# Altium schematic sheet [01]_PWR.SchDoc([01]_PWR)
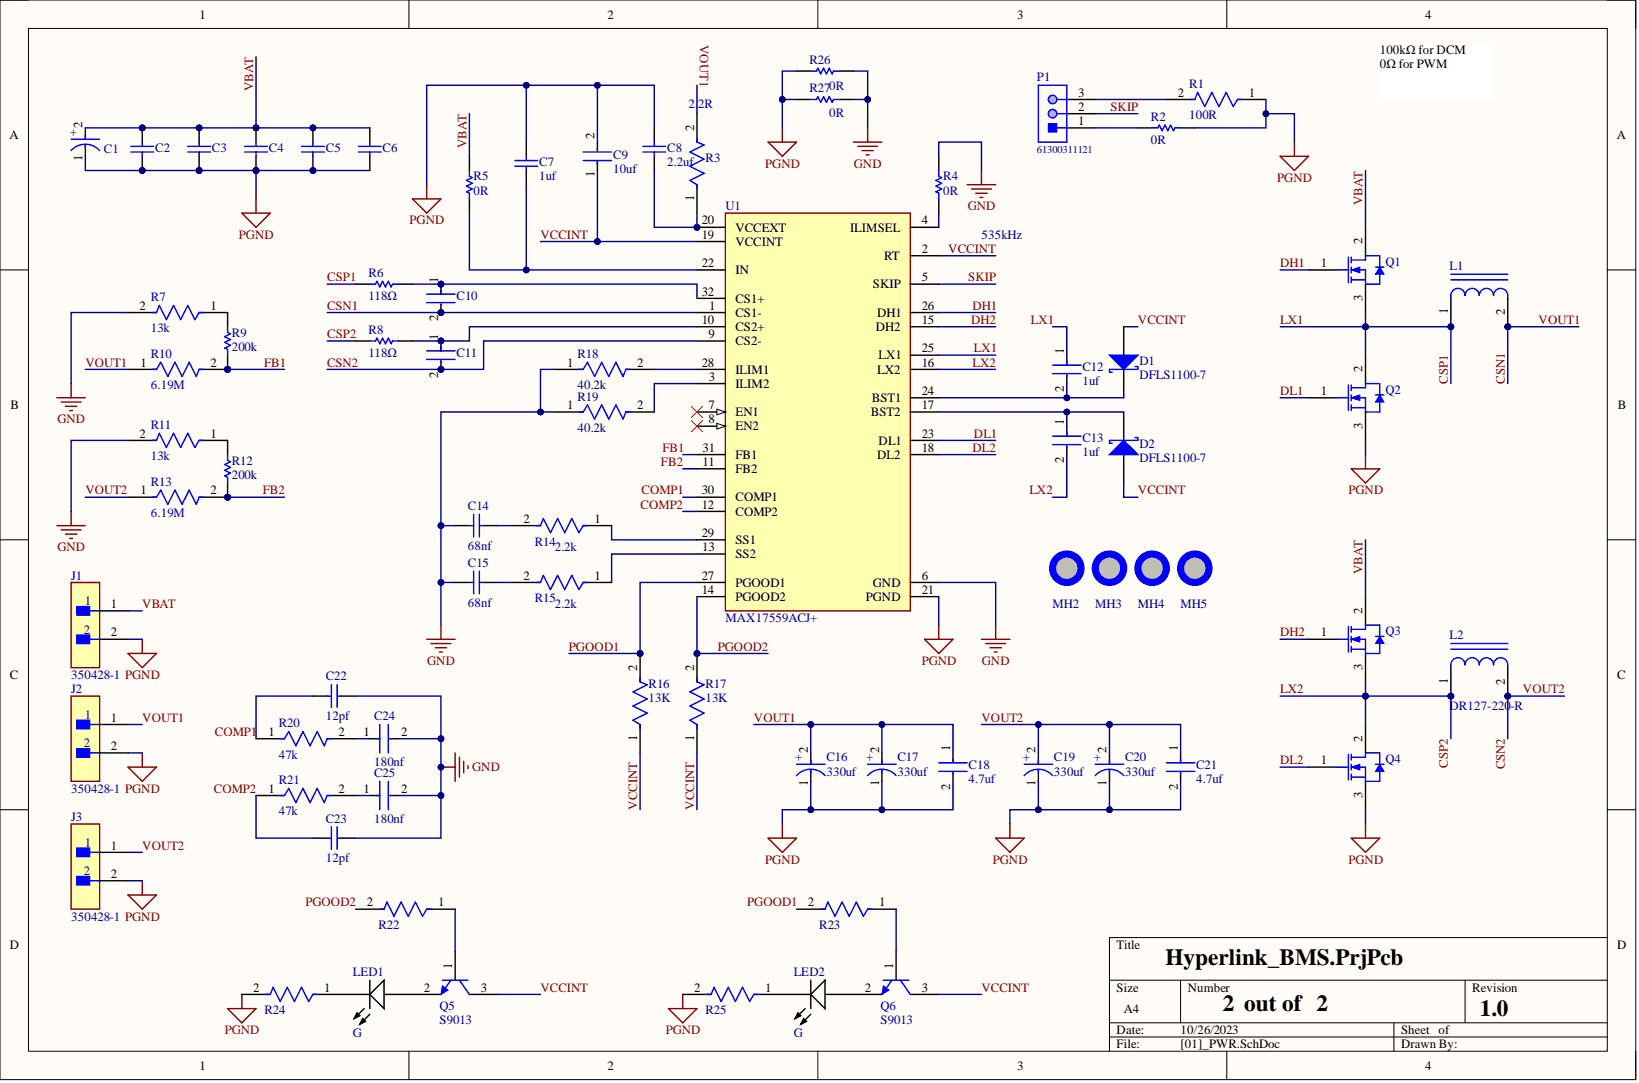
[diagram p0: [01]_PWR.SchDoc]

## Parts
C1  220uf  (value not drawn)
C2  CAP 4.7uF 25V 1210(3225)  (value not drawn)
C3  CAP 4.7uF 25V 1210(3225)  (value not drawn)
C4  CAP 4.7uF 25V 1210(3225)  (value not drawn)
C5  CAP 4.7uF 25V 1210(3225)  (value not drawn)
C6  CAP 4.7uF 25V 1210(3225)  (value not drawn)
C7  1uf
C8  2.2uf
C9  10uf
C10  4.7uF  (value not drawn)
C11  4.7uF  (value not drawn)
C12  1uf
C13  1uf
C14  68nf
C15  68nf
C16  330uf
C17  330uf
C18  4.7uf
C19  330uf
C20  330uf
C21  4.7uf
C22  12pf
C23  12pf
C24  180nf
C25  180nf
D1  DFLS1100-7
D2  DFLS1100-7
J1  350428-1
J2  350428-1
J3  350428-1
L1  DR127-220-R
L2  DR127-220-R
LED1  G
LED2  G
MH2  MH_7.4MMNC_3.2MMNC  (value not drawn)  (no BOM)
MH3  MH_7.4MMNC_3.2MMNC  (value not drawn)  (no BOM)
MH4  MH_7.4MMNC_3.2MMNC  (value not drawn)  (no BOM)
MH5  MH_7.4MMNC_3.2MMNC  (value not drawn)  (no BOM)
P1  61300311121
Q1  IPB60R520CP  (value not drawn)
Q2  IPB60R520CP  (value not drawn)
Q3  IPB60R520CP  (value not drawn)
Q4  IPB60R520CP  (value not drawn)
Q5  S9013
Q6  S9013
R1  100R
R2  0R
R3  2.2R
R4  0R
R5  0R
R6  118Ω
R7  13k
R8  118Ω
R9  200k
R10  6.19M
R11  13k
R12  200k
R13  6.19M
R14  2.2k  (value not drawn)
R15  2.2k  (value not drawn)
R16  13K
R17  13K
R18  40.2k
R19  40.2k
R20  47k
R21  47k
R22  0603WAF1200T5E  (value not drawn)
R23  0603WAF1200T5E  (value not drawn)
R24  CRCW06031K00FKEA  (value not drawn)
R25  CRCW06031K00FKEA  (value not drawn)
R26  0R
R27  0R
U1  MAX17559ACJ+

## Nets
GND: C14.1 C15.1 C22.2 C23.2 C24.2 C25.2 R4.1 R7.2 R11.2 R18.1 R19.1 R26.2 R27.2 U1.6(GND)
PGND: C1.1 C2.1 C3.1 C4.1 C5.1 C6.1 C7.2 C8.2 C9.2 C16.1 C17.1 C18.2 C19.1 C20.1 C21.2 J1.2 J2.2 J3.2 Q2.3 Q4.3 R1.1 R2.1 R24.2 R25.2 R26.1 R27.1 U1.21(PGND)
COMP1: C22.1 R20.1 U1.30(COMP1)
COMP2: C23.1 R21.1 U1.12(COMP2)
CSN1: C10.2 C16.2 C17.2 C18.1 J2.1 L1.2 R3.2 R10.1 U1.1(CS1-)
CSN2: C11.2 C19.2 C20.2 C21.1 J3.1 L2.2 R13.1 U1.9(CS2-)
CSP1: C12.1 L1.1 Q1.3 Q2.2 R6.1 U1.25(LX1)
CSP2: C13.2 L2.1 Q3.3 Q4.2 R8.1 U1.16(LX2)
DH1: Q1.1 U1.26(DH1)
DH2: Q3.1 U1.15(DH2)
DL1: Q2.1 U1.23(DL1)
DL2: Q4.1 U1.18(DL2)
FB1: R9.1 R10.2 U1.31(FB1)
FB2: R12.1 R13.2 U1.11(FB2)
PGOOD1: R16.2 R23.2 U1.27(PGOOD1)
PGOOD2: R17.2 R22.2 U1.14(PGOOD2)
SKIP: P1.2 U1.5(SKIP)
VBAT: C1.2 C2.2 C3.2 C4.2 C5.2 C6.2 J1.1 Q1.2 Q3.2 R5.1
VCCINT: C9.1 D1.1 D2.1 Q5.3 Q6.3 R16.1 R17.1 U1.2(RT) U1.19(VCCINT)
NetC7_1: C7.1 R5.2 U1.22(IN)
NetC8_1: C8.1 R3.1 U1.20(VCCEXT)
NetC10_1: C10.1 R6.2 U1.32(CS1+)
NetC11_1: C11.1 R8.2 U1.10(CS2+)
NetC12_2: C12.2 D1.2 U1.24(BST1)
NetC13_1: C13.1 D2.2 U1.17(BST2)
NetC14_2: C14.2 R14.2
NetC15_2: C15.2 R15.2
NetC24_1: C24.1 R20.2
NetC25_1: C25.1 R21.2
NetLED1_1: LED1.1 Q5.2
NetLED1_2: LED1.2 R24.1
NetLED2_1: LED2.1 Q6.2
NetLED2_2: LED2.2 R25.1
NetP1_1: P1.1 R2.2
NetP1_3: P1.3 R1.2
NetQ5_1: Q5.1 R22.1
NetQ6_1: Q6.1 R23.1
NetR4_2: R4.2 U1.4(ILIMSEL)
NetR7_1: R7.1 R9.2
NetR11_1: R11.1 R12.2
NetR14_1: R14.1 U1.29(SS1)
NetR15_1: R15.1 U1.13(SS2)
NetR18_2: R18.2 U1.28(ILIM1)
NetR19_2: R19.2 U1.3(ILIM2)

Not connected: U1 7(EN1) 8(EN2)

## Library data of the parts
C1 = CMP-05427-000076-1: CAP ALUM 220UF 20% 100V SMD; Dielectric Material: Aluminium; ESR (Equivalent Series Resistance): 153mR; Leakage Current: 3uA; Life (Hours): 5000Hour; Ripple Current: 917mA; Capacitance: 220uF; Case/Package: Radial; Component Kind: Standard; Construction: Cylindrical; Contact Plating: Tin; Diameter: 18mm; Height: 16.5mm; Height - Seated (Max): 0.65inch; Impedance: 153mR; JLC: C340711; Lead Free: Lead Free; Length: 17mm; Library Name: Altium Content Vault; Max Operating Temperature: 105°C; Min Operating Temperature: -55°C; Mount: Surface Mount; Number of Pins: 2; Package Quantity: 1; Packaging: Tape and Reel; Radiation Hardening: No; REACH SVHC: No SVHC; Ripple Current (AC): 917mA; RoHS Compliant: Yes; Series: FK; Termination: SMD/SMT; Tolerance: 20%; Voltage: 100V; Voltage Rating: 100V; Voltage Rating (DC): 100V; Width: 1.2mm
C2-C6 = CMP-1038-02151-2: CAP 4.7uF 25V ±10% 1210 (3225 Metric) Thickness 2.2mm SMD; Case-EIA: 1210; Case-Metric: 3225; Tolerance: Â±10%; Component Kind: Standard; JLC: C2840282; Library Name: Altium Content Vault; Max Thickness: 2.2 mm; Rated Voltage: 25 V; Technology: SMT; Value: 4.7uF
C7 = CMP-2000-06366-1: Chip Capacitor, 1 uF, +/- 10%, 100 V, -55 to 125 degC, 1210 (3225 Metric), RoHS, Tape and Reel; Manufacturer: Murata; Capacitance: 1µF; Catalog Drawings: GRMSeries_1210_2.5; Featured Product: GA3SafetyRecognizedCapsandHi-CapMLCC; Online Catalog: GRMSeries; Other Names: 490-1857-6; Product Training Modules: High-CapMultilayerCeramicCapacitors; Temperature Coefficient: X7R; Voltage - Rated: 100V; Applications: GeneralPurpose; Category: Capacitors; Component Kind: Standard; Datasheet URL: http://search.murata.co.jp/Ceramy/image/img/A01X/G101/ENG/GRM32ER72A105KA01-01.pdf; Datasheet Version: 02/2016; Family: CeramicCapacitors; Features: -; Height - Seated (Max): -; JLC: C13832; Lead Spacing: -; Lead Style: -; Library Name: Altium Content Vault; Manufacturer URL: http://www.murata.com/; Mounting Technology: SurfaceMount; Mounting Type: SurfaceMount,MLCC; Operating Temperature: -55°C~125°C; Package / Case: 1210(3225Metric); Package Description: 2-Pin Surface Mount Device, Body 3.2 x 2.5 mm; Package Reference: 1210; Packaging: Digi-Reel®; Ratings: -; Series: GRM; Size / Dimension: 0.126"Lx0.098"W(3.20mmx2.50mm); Standard Package: 1; Thickness (Max): 0.106"(2.70mm); Tolerance: ±10%
C8 = CMP-2007-03455-1: Manufacturer: Murata; Part Number: GRM21BR71C225KA12L; Component Kind: Standard; JLc: C49217; Library Name: Altium Content Vault
C9 = CMP-2006-02792-2: Chip Multilayer Ceramic Capacitors for General Purpose, 0603, 10uF, X5R, 15%, 20%, 6.3V; Capacitance: 10uF; Case Code (Imperial): 0603; Case Code (Metric): 1608; Case/Package: 0603; Component Kind: Standard; Depth: 0.8mm; Dielectric: X5R; Height: 0.8mm; JLC: C19702; Lead Free: Lead Free; Length: 1.6mm; Library Name: Altium Content Vault; Material: Ceramic; Max Operating Temperature: 85°C; Min Operating Temperature: -55°C; Mount: Surface Mount; Package Quantity: 4000; Packaging: Tape and Reel; Radiation Hardening: No; REACH SVHC: No SVHC; Termination: SMD/SMT; Thickness: 0.035inch; Tolerance: 20%; Voltage: 6.3V; Voltage Rating: 6.3V; Voltage Rating (DC): 6.3V; Width: 0.031inch
C10 C11 C18 C21 = CMP-14477-004926-2: Multilayer Ceramic Capacitor 4.7uF 25V X5R 10% SMD 0603 T/R; Capacitance: 4.7uF; Case Code (Imperial): 0603; Case Code (Metric): 1608; Case/Package: 0603; Component Kind: Standard; Contact Plating: Tin; Dielectric: X5R; JLC: C19666; Lead Free: Lead Free; Length: 0.063inch; Library Name: Altium Content Vault; Max Operating Temperature: 85°C; Min Operating Temperature: -55°C; Mount: Surface Mount; Packaging: Tape and Reel; RoHS Compliant: Yes; Termination: Wraparound; Thickness: 0.039inch; Tolerance: 10%; Voltage Rating: 25V; Width: 0.031inch
C12 C13 = CMP-2006-02256-2: CAP CER 4.7UF 6.3V X5R 0603; Manufacturer: Kemet; Manufacturer Part Number: C0603C475K9PACTU; Material: Ceramic; Temperature Coefficient: 15%; Tolerance: 10%; Automotive: No; Capacitance: 4.7uF; Category: Cap; Component Kind: Standard; ComponentLink2Description: Datasheet; ComponentLink2URL: https://content.kemet.com/datasheets/KEM_C1006_X5R_SMD.pdf; Device Class L1: Passive Components; Device Class L2: Capacitors; Device Class L3: Ceramic Capacitors; Digikey Description: CAP CER 4.7UF 6.3V X5R 0603; Height: 0.9mm; Ipc Land Pattern Name: CAPC16080X80; JLC: C15849; Lead Free: Yes; Library Name: Altium Content Vault; Mouser Description: Multilayer Ceramic Capacitors MLCC - SMD/SMT 6.3volts 4.7uF X5R 10%; Octopart: https://octopart.com/c0603c475k9pactu-kemet-104242; Package: C0603-CG; RoHS: TRUE; Temperature: -55°C to +85°C; Temperature Characteristic: X5R; Temperature Range High: +85°C; Temperature Range Low: -55°C; Value: 4.7uF; Voltage Rating: 6.3V
C14 C15 = CMP-2006-02098-1: Manufacturer: AVX Interconnect / Elco; Part Number: 0603YC683KAZ2A; Component Kind: Standard; JLC: C31658; Library Name: Altium Content Vault
C16 C17 C19 C20 = CMP-05427-000096-1: CAP ALUM 330UF 20% 35V SMD; Dielectric Material: Aluminium; ESR (Equivalent Series Resistance): 80mR; Lead Diameter: 0.9mm; Leakage Current: 115.5uA; Life (Hours): 2000Hour; Ripple Current: 850mA; Capacitance: 330uF; Case/Package: Radial; Component Kind: Standard; Construction: Cylindrical; Contact Plating: Tin; Diameter: 8mm; Features: General Purpose; Height: 10.2mm; Height - Seated (Max): 0.413inch; JLC: C310848; Lead Free: Lead Free; Lead Pitch: 3.1mm; Length: 10.2mm; Library Name: Altium Content Vault; Max Operating Temperature: 105°C; Min Operating Temperature: -55°C; Mount: Surface Mount; Number of Pins: 2; Package Quantity: 500; Packaging: Tape and Reel; Radiation Hardening: No; REACH SVHC: No SVHC; Ripple Current (AC): 850mA; RoHS Compliant: Yes; Series: FT; Termination: SMD/SMT; Tolerance: 20%; Voltage: 35V; Voltage Rating: 35V; Voltage Rating (DC): 35V
C22 C23 = CMP-2006-04614-1: Manufacturer: AVX Interconnect / Elco; Part Number: 06035J120GBSTR; Component Kind: Standard; JLC: C38523; Library Name: Altium Content Vault
C24 C25 = CMP-1035-02634-3: CAP CER 0.18UF 25V X7R 0603; Manufacturer: KEMET; Manufacturer Part Number: C0603C184K3RACTU; Automotive Grade: Grade 1; Capacitance: 0.18 μF; Material: Ceramic; Temperature Coefficient: 30ppm/°C; Tolerance: 0.1; Value: 0.18 μF; Automotive: Yes; Category: Cap; Component Kind: Standard; ComponentLink2Description: Datasheet; ComponentLink2URL: https://api.kemet.com/component-edge/download/datasheet/C0603C100J5GACAUTO.pdf; Device Class L1: Passive Components; Device Class L2: Capacitors; Device Class L3: Ceramic Capacitors; Digikey Description: CAP CER 0.18UF 25V X7R 0603; Height: 0.87mm; JLC: C605213; Lead Free: Yes; Library Name: Altium Content Vault; Octopart: https://octopart.com/c0603c184k3ractu-kemet-19757378; Package: C0603C-CF; RoHS: TRUE; Temperature: -55°C to +125°C; Temperature Characteristic: X7R; Temperature Range High: +125°C; Temperature Range Low: -55°C; Voltage Rating: 25 V
D1 D2 = CMP-2000-05099-1: 1 A High Voltage Schottky Barrier Rectifier, 0.62 V, -55 to 175 degC, 2- Pin POWERDI123, Pb-Free, Tape and Reel; Manufacturer: Diodes Inc; Average Rectified Current-Max: 1A; Forward Voltage: 0.77V; Peak Current-Max: 40A; Reverse Current-Max: 1µA; Reverse Voltage-Max [Vrrm]: 100V; Component Kind: Standard; Datasheet URL: https://datasheet.ciiva.com/15144/getdatasheetpartid-44146-15144126.pdf; Datasheet Version: Sep-14; JLC: C107674; Library Name: Altium Content Vault; Manufacturer URL: http://www.diodes.com/
J1-J3 = CMP-03369-000080-1: CONN HEADER VERT 2POS 6.35MM; Manufacturer: TE Connectivity; Conn Gender: Male; Conn Orientation: Vertical; Current Rating: 12A; Number Of Contacts: 2; Standoff Height: 0.63mm; Voltage Rating: 600V; Automotive: No; Category: Conn; Component Kind: Standard; ComponentLink2Description: Datasheet; ComponentLink2URL: https://www.te.com/commerce/DocumentDelivery/DDEController?Action=showdoc&DocId=Customer+Drawing%7F350428%7FY2%7Fpdf%7FEnglish%7FENG_CD_350428_Y2.pdf%7F350428-1; Contact Material: Gold,Nickel; Device Class L1: Connectors; Device Class L2: Headers and Wire Housings; Device Class L3: unset; Digikey Description: CONN HEADER VERT 2POS 6.35MM; Height: 14.99mm; JLC: C576280; Lead Free: Yes; Library Name: Altium Content Vault; Manufacturer Part Number: 350428-1; Mouser Description: Connector, Soft Shell; Universal MATE-N-LOK; 2; Nylon; Natural; 19 A; 600 VAC; Number Of Rows: 1; Octopart: https://octopart.com/350428-1-te+connectivity-46505355; Package: 350428-1; Pitch: 6.35mm; RoHS: TRUE; Temperature: -40°C to +105°C; Temperature Range High: +105°C; Temperature Range Low: -40°C
L1 L2 = CMP-16092-000013-1: FIXED IND 22UH 4A 39.1 MOHM SMD; Core Material: Ferrite; DC Resistance (DCR): 39.1mR; Inductance Tolerance: 20%; Inductor Type: POWER; Max DC Current: 7.57A; Self Resonant Frequency: 100kHz; Shielding: Shielded; Case/Package: Nonstandard; Component Kind: Standard; Current Rating: 4A; DC Current: 4A; Depth: 12.5mm; Height: 8mm; Height - Seated (Max): 0.315inch; Inductance: 22uH; JLC: C526021; Lead Free: Lead Free; Length: 12.5mm; Library Name: Altium Content Vault; Max Operating Temperature: 125°C; Military Standard: Not; Min Operating Temperature: -40°C; Mount: Surface Mount; Number of Pins: 2; Package Quantity: 350; Packaging: Tape and Reel; Radiation Hardening: No; Rated Current-Max: 4A; Resistor Type: Wirewound; RMS Current (Irms): 4A; RoHS Compliant: Yes; Saturation Current: 7.57A; Series: DR; Termination: SMD/SMT; Test Frequency: 100kHz; Tolerance: 20%; Weight: 0.224oz; Width: 0.492inch
LED1 LED2 = CMP-1744-00001-1: LED Uni-Color GREEN, 78 mW, 20 mA, -40 to 85 degC, 2-Pin SMD, RoHS, Tape and Reel; Current Rating: 300 mA; Component Kind: Standard; ComponentLink1Description: Manufacturer URL; ComponentLink1URL: http://www.avagotech.com/; ComponentLink2Description: Datasheet; ComponentLink2URL: http://docs.avagotech.com/docs/AV02-0186EN; Contact Finish: Silver; Contact Material: Copper; Contact Plating: Silver; DatasheetVersion: May-10; Height: 12 mm; JLC: C72043; Length: 16 mm; Library Name: Altium Content Vault; Max Operating Temperature: 85 degC; Min Operating Temperature: -20 degC; Mount: Through Hole; Package Quantity: 560; PackageDescription: 2-Pin Surface Mount Device, Body 1.6 x 0.8 mm; PackageReference: HSMW-C191; Packaging: Bulk; Radiation Hardening: No; REACH SVHC: Unknown; RoHSCompliant: true; Width: 12 mm
MH2-MH5 = MH_7.4MMNC_3.2MMNC: Hole 7.4mm Diameter (No Copper), 3.2mm Drill NPTH; Library Name: Hyperlink_Int_Library.IntLib
P1 = CMP-1502-01062-1: THT Vertical Pin Header WR-PHD, Pitch 2.54 mm, Single Row, 3 pins; Manufacturer: Wurth Elektronik; Contact Resistance: 20mOhm; Gender: Male; Pin Number: 3; Pitch: 2.54mm; Rated Current: 3A; Withstanding Voltage: 500VAC; Working Voltage: 250VAC; Component Kind: Standard; Datasheet URL: https://katalog.we-online.de/em/datasheet/6130xx11121.pdf; JLC: C429954; Library Name: Altium Content Vault; Manufacturer URL: http://www.we-online.de; Mounting Technology: THT; Operating Temperature: -40to105degC; Orientation: Vertical; Package Reference: 61300311121; Packaging: Bag; Part Number: 61300311121; Row Number: Single; Publisher: WurthElektronik
Q1-Q4 = CMP-1164-00463-1: N-Channel CoolMOS Power-Transistor, 600 V VDS, 6.8 A ID, -55 to 150 degC, PG-TO263-3-2, Reel, Green; Manufacturer: Infineon; Green: TRUE; ID (max): 6.8Â A; IDpuls (max): 17Â A; Polarity: N; Ptot (max): 66Â W; QG: 24Â nC; RDS (on) (max): 520Â mOhm; Rth: 1.9Â K/W; RthJA (max): 62Â K/W; VDS (max): 600Â V; VGS(th) (max): 3.5Â V; VGS(th) (min): 2.5Â V; Component Kind: Standard; ComponentLink1Description: Manufacturer URL; ComponentLink1URL: http://www.infineon.com/; ComponentLink2Description: Datasheet; ComponentLink2URL: http://www.infineon.com/dgdl/IPB60R520CP_rev2.0.pdf?folderId=db3a3043163797a6011637d4bae7003b&fileId=db3a3043183a95550118792e20dc045d; ComponentLink3Description: Package Specification; ComponentLink3URL: http://www.infineon.com/dgdl/BTS141TC_DS_10.pdf?folderId=db3a3043163797a6011667aa084c0e01&fileId=db3a3043242ebc9e01242f43f58b003e&location=en.Products.Automotive_ICs.Smart_High-_and_Low-Side_Switches.HITFET___TEMPFET__-_Smart_Low-Side_Switches.DATASHEET.BT; DatasheetVersion: Rev. 2.0; Halogen-free: TRUE; JLC: C341720; Library Name: Altium Content Vault; Mounting Technology: Surface Mount; PackageDescription: 3-Pin Plastic (Green) Transistor Single Outline Package, 10 x 9.25 mm Body, 2.54 mm Pitch; PackageReference: PG-TO263-3-2; PackageVersion: Jul-09; Packing: Reel; PartNumber: IPB60R520CP; RthJC (max): 1.9Â K/W; Technology: CoolMOS CP
Q5 Q6 = CMP-1048-00662-1: NPN Silicon, 3-Pin SOT-23, Pb-Free, Tape and Reel; Manufacturer: On Semiconductor; PartNumber: MMBTA42LT1G; fT Min (MHz): 50; IC Continuous (A): 0.5; Component Kind: Standard; ComponentLink1Description: Manufacturer URL; ComponentLink1URL: http://www.onsemi.com/; ComponentLink2Description: Datasheet; ComponentLink2URL: http://www.onsemi.cn/pub_link/Collateral/MMBTA42LT1-D.PDF; ComponentLink3Description: Package Specification; ComponentLink3URL: http://www.onsemi.cn/pub_link/Collateral/318-08.PDF; DatasheetVersion: Rev. 12; JLC: C6749; Library Name: Altium Content Vault; Mounting Technology: Surface Mount; PackageDescription: 3-Pin Small Outline Transistor, Body 2.92 x 1.3 mm, Pitch 0.95 mm; PackageReference: SOT-23-3-318-08; PackageVersion: Rev. AP, 11/2009; Packing: Tape and Reel; Polarity: NPN; RoHS: TRUE
R1 = CMP-2000-03163-2: RES Thick Film, 100Ω, 5%, 0.1W, 200ppm/°C, 0603; Max Power Dissipation: 100mW; Voltage Rating: 75V; Case Code (Imperial): 0603; Case Code (Metric): 1608; Case/Package: 0603; Component Kind: Standard; Composition: Thick Film; Contact Plating: Tin; Depth: 0.85mm; Features: Automotive AEC-Q200; Height: 0.45mm; JLC: C22775; Lead Free: Lead Free; Length: 1.55mm; Library Name: Altium Content Vault; Max Operating Temperature: 155°C; Military Standard: Not; Min Operating Temperature: -55°C; Mount: Surface Mount; Number of Pins: 2; Number of Terminations: 2; Package Quantity: 1; Packaging: Tape and Reel; Power Rating: 100mW; Radiation Hardening: No; Resistance: 100R; Temperature Coefficient: 200ppm/°C; Termination: SMD/SMT; Tolerance: 5%; Voltage Rating (DC): 75V; Weight: 7.1E-05oz; Width: 0.033inch; Working Voltage: 75V
R2 R4 R5 R26 R27 = CMP-2000-03816-5: Chip Resistor, 0 Ohm, Jumper, 100 mW, -55 to 155 degC, 0603 (1608 Metric), RoHS, Tape and Reel; Manufacturer: Vishay Semiconductor; Voltage Rating: 75 V; Component Kind: Standard; ComponentLink1Description: Manufacturer URL; ComponentLink1URL: http://www.vishay.com/; ComponentLink2Description: Datasheet; ComponentLink2URL: http://www.vishay.com/docs/20035/dcrcwe3.pdf; DatasheetVersion: 06/2012; Height: 0.45 mm; JLC: C21189; Length: 1.55 mm; Library Name: Altium Content Vault; Max Operating Temperature: 155 degC; Min Operating Temperature: -55 degC; Mount: Surface Mount; Mounting Technology: Surface Mount; Package Quantity: 5000; PackageDescription: 2-Pin Surface Mount Device, Body 1.575 x 0.85 mm; PackageReference: 0603; Packaging: Tape and Reel; Pins: 2; REACH SVHC: Unknown; RoHSCompliant: true
R3 R14 R15 = CMP-02407-010809-1: RES Thick Film, 2.2kΩ, 1%, 0.33W, 100ppm/°C, 0603; Max Power Dissipation: 250mW; Resistance: 2.2kR; Voltage Rating: 75V; Case Code (Imperial): 0603; Case Code (Metric): 1608; Case/Package: 0603; Component Kind: Standard; Composition: Thick Film; Contact Plating: Tin; Depth: 0.85mm; Features: Automotive AEC-Q200; Height: 0.02inch; JLC: C4190; Lead Free: Lead Free; Length: 1.6mm; Library Name: Altium Content Vault; Max Operating Temperature: 155°C; Military Standard: Not; Min Operating Temperature: -55°C; Mount: Surface Mount; Number of Terminations: 2; Package Quantity: 1; Packaging: Tape and Reel; Power Rating: 250mW; Radiation Hardening: No; Temperature Coefficient: 100ppm/°C; Termination: Solder; Tolerance: 1%; Voltage Rating (DC): 75V; Width: 0.033inch
R6 R8 = CMP-2000-03859-1: Manufacturer: Vishay Dale; Part Number: CRCW0603118RFKEA; Component Kind: Standard; JLC: C177686; Library Name: Altium Content Vault
R7 R11 = CMP-2000-03898-2: RES Thick Film, 13.3kΩ, 1%, 0.1W, 100ppm/°C, 0603; Max Power Dissipation: 100mW; Resistance: 13.3kR; Voltage Rating: 75V; Case Code (Imperial): 0603; Case Code (Metric): 1608; Case/Package: 0603; Component Kind: Standard; Composition: Thick Film; Contact Plating: Tin; Depth: 0.85mm; Features: Automotive AEC-Q200; Height: 0.45mm; JLC: C22797; Lead Free: Lead Free; Length: 1.55mm; Library Name: Altium Content Vault; Max Operating Temperature: 155°C; Military Standard: Not; Min Operating Temperature: -55°C; Number of Terminations: 2; Package Quantity: 5000; Packaging: Tape and Reel; Power Rating: 100mW; Radiation Hardening: No; Temperature Coefficient: 100ppm/°C; Termination: SMD/SMT; Tolerance: 1%; Voltage Rating (DC): 75V; Weight: 7.1E-05oz; Width: 0.033inch
R9 R12 = CMP-1012-00129-2: Manufacturer: Panasonic; Part Number: ERJ-3GEYJ204V; Component Kind: Standard; JLc: C22977; Library Name: Altium Content Vault
R10 R13 = CMP-04015-103560-1: 6.19MΩ ±1% 0.1W Chip Resistor 0603 Flat Chip (Anti-Sulfuration) AEC-Q200 Qualified; Power Rating: 100mW; Resistance: 6.19MR; Temperature Coefficient: 200ppm/°C; Voltage Rating: 75V; Case Code (Imperial): 0603; Case Code (Metric): 1608; Case/Package: 0603; Component Kind: Standard; Height: 0.45mm; JLC: C482890; Length: 1.6mm; Library Name: Altium Content Vault; Max Operating Temperature: 155°C; Min Operating Temperature: -55°C; Package Quantity: 5000; Packaging: Tape and Reel; Termination: SMD/SMT; Tolerance: 1%; Weight: 7.1E-05oz; Width: 0.8mm
R16 R17 = CMP-2000-03840-2: RES Thick Film, 13kΩ, 1%, 0.1W, 100ppm/°C, 0603; Max Power Dissipation: 100mW; Resistance: 13kR; Voltage Rating: 75V; Case Code (Imperial): 0603; Case Code (Metric): 1608; Case/Package: 0603; Component Kind: Standard; Composition: Thick Film; Contact Plating: Tin; Depth: 0.85mm; Features: Automotive AEC-Q200; Height: 0.45mm; JLC: C22797; Lead Free: Lead Free; Length: 1.55mm; Library Name: Altium Content Vault; Max Operating Temperature: 155°C; Military Standard: Not; Min Operating Temperature: -55°C; Number of Terminations: 2; Package Quantity: 10; Packaging: Tape and Reel; Power Rating: 100mW; Radiation Hardening: No; Temperature Coefficient: 100ppm/°C; Termination: SMD/SMT; Tolerance: 1%; Voltage Rating (DC): 75V; Weight: 7.1E-05oz; Width: 0.033inch
R18-R21 = CMP-2000-03336-2: RES Thick Film, 40.2kΩ, 1%, 0.1W, 100ppm/°C, 0603; Power Rating: 100mW; Resistance: 40.2kR; Voltage Rating: 75V; Case Code (Imperial): 0603; Case Code (Metric): 1608; Case/Package: 0603; Component Kind: Standard; Composition: Thick Film; Contact Plating: Tin; Depth: 0.85mm; Height: 0.45mm; Lead Free: Lead Free; Length: 1.55mm; Library Name: Altium Content Vault; Max Operating Temperature: 155°C; Military Standard: Not; Min Operating Temperature: -55°C; Package Quantity: 5000; Packaging: Tape and Reel; Radiation Hardening: No; Temperature Coefficient: 100ppm/°C; Termination: SMD/SMT; Tolerance: 1%; Voltage Rating (DC): 75V; Weight: 7.1E-05oz; Width: 0.85mm
  R18 R19: JLC: C12447
  R20 R21: JLC: C25819
R22 R23 = CMP-1012-00052-3: RES Thick Film, 120Ω, 5%, 0.1W, 200ppm/°C, 0603; Max Power Dissipation: 100mW; Resistance: 120R; Voltage Rating: 75V; Case Code (Imperial): 0603; Case Code (Metric): 1608; Case/Package: 0603; Component Kind: Standard; Composition: Thick Film; Contact Plating: Tin; Depth: 0.85mm; Features: Automotive AEC-Q200; Height: 0.02inch; JLC: C22787; Lead Free: Lead Free; Length: 1.55mm; Library Name: Altium Content Vault; Max Operating Temperature: 155°C; Military Standard: Not; Min Operating Temperature: -55°C; Number of Pins: 2; Number of Terminations: 2; Package Quantity: 1; Packaging: Tape and Reel; Power Rating: 100mW; Radiation Hardening: No; Temperature Coefficient: 200ppm/°C; Termination: SMD/SMT; Tolerance: 5%; Voltage Rating (DC): 75V; Weight: 7.1E-05oz; Width: 0.85mm
R24 R25 = CMP-1666-00003-5: RES Thick Film, 1kΩ, 1%, 0.1W, 100ppm/°C, 0603; Max Power Dissipation: 100mW; Resistance: 1kR; Voltage Rating: 75V; Case Code (Imperial): 0603; Case Code (Metric): 1608; Case/Package: 0603; Component Kind: Standard; Composition: Thick Film; Contact Plating: Tin; Depth: 0.85mm; Features: Automotive AEC-Q200; Height: 0.45mm; JLC: C21190; Lead Free: Lead Free; Length: 1.55mm; Library Name: Altium Content Vault; Max Operating Temperature: 155°C; Military Standard: Not; Min Operating Temperature: -55°C; Mount: Surface Mount; Number of Pins: 2; Number of Terminations: 2; Package Quantity: 10; Packaging: Tape and Reel; Power Rating: 100mW; Radiation Hardening: No; Temperature Coefficient: 100ppm/°C; Termination: SMD/SMT; Tolerance: 1%; Voltage Rating (DC): 75V; Weight: 7.1E-05oz; Width: 0.033inch
U1 = CMP-05722-000248-1: IC REG CTRLR BUCK 32TQFP; Clock Sync: No; Number of Output Phases: 2; Output Configuration: Positive; Switching Frequency: 2.2MHz; Synchronous Rectifier: Yes; Case/Package: TQFP; Component Kind: Standard; Library Name: Altium Content Vault; Max Operating Temperature: 125°C; Min Operating Temperature: -40°C; Number of Outputs: 2; Number of Pins: 32; Packaging: Tray; RoHS Compliant: Yes
